# Altium schematic sheet Power.SchDoc
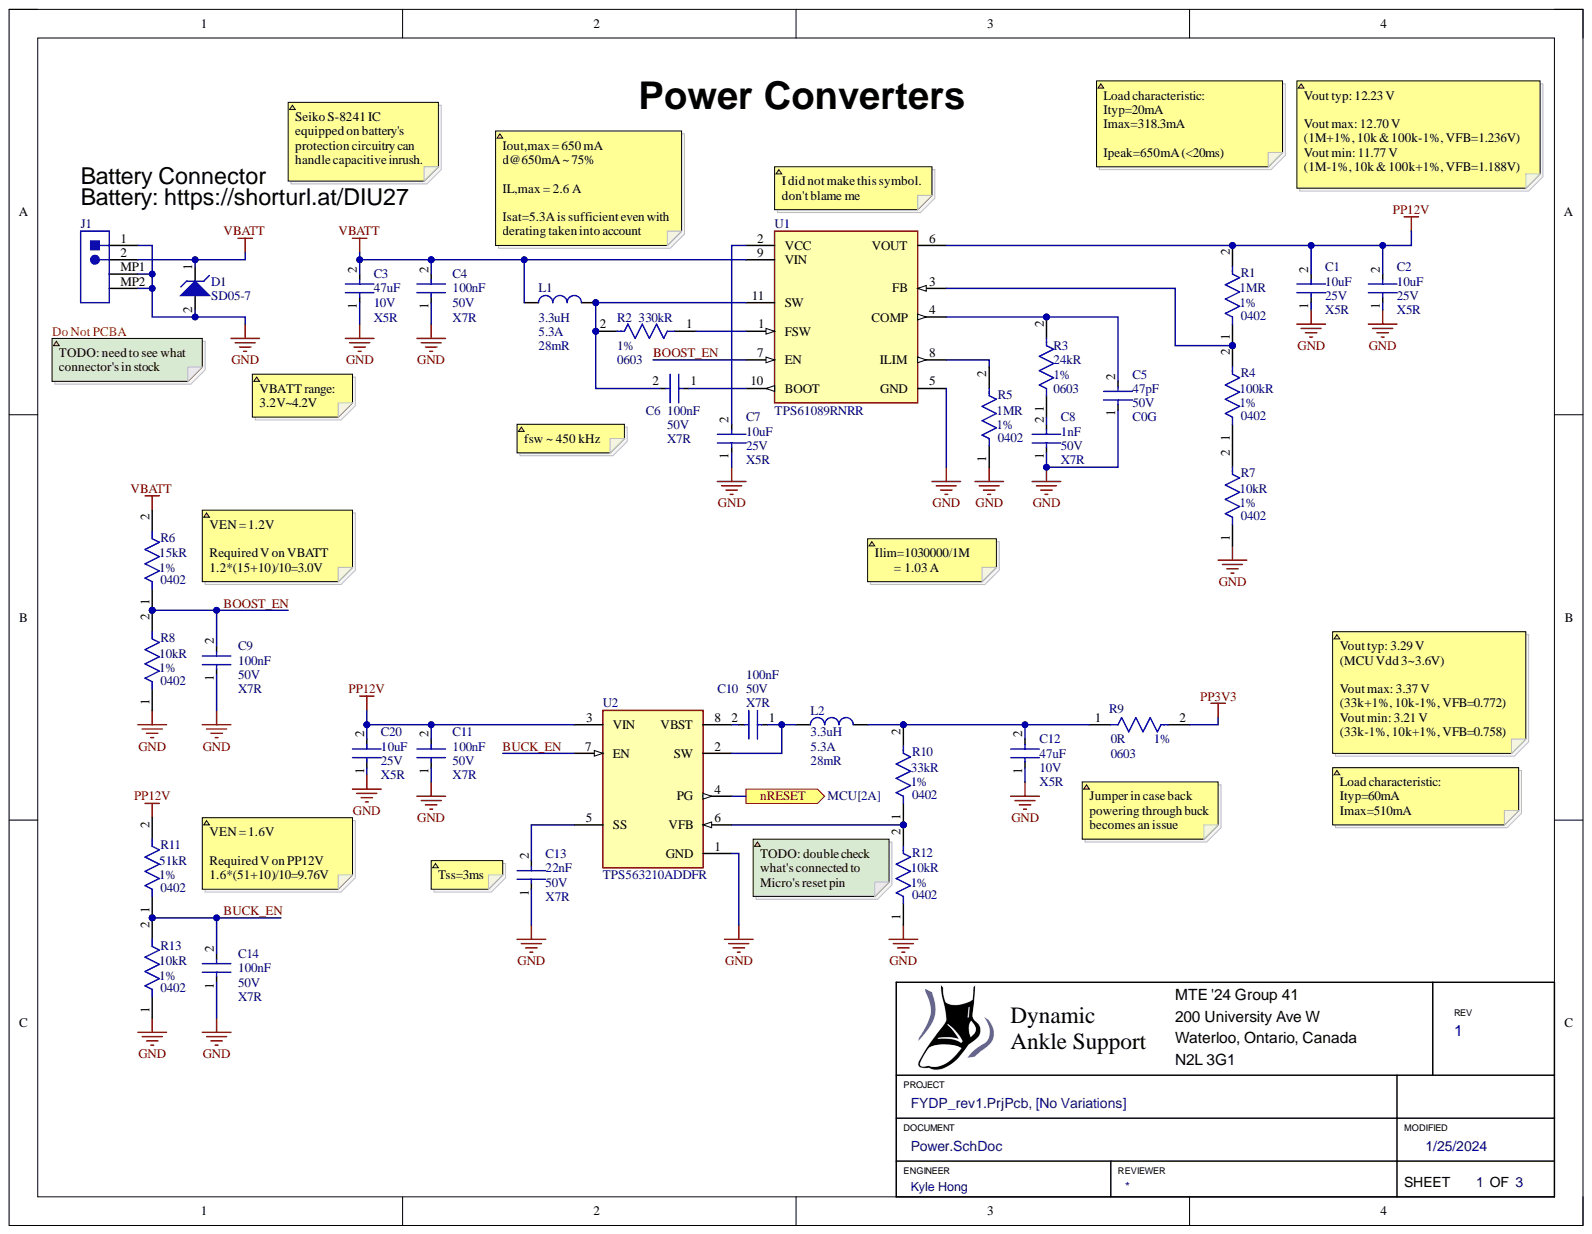
[diagram p0: Power.SchDoc]

## Parts
C1  Capacitance: 10uF  Dielectric: X5R  Voltage Rating: 25V  [CAP0805]  (hidden comment: CL21A106KAYNNNE)
C2  Capacitance: 10uF  Dielectric: X5R  Voltage Rating: 25V  [CAP0805]  (hidden comment: CL21A106KAYNNNE)
C3  Capacitance: 47uF  Dielectric: X5R  Voltage Rating: 10V  [CAP1206]  (hidden comment: CL31A476MPHNNNE)
C4  Capacitance: 100nF  Dielectric: X7R  Voltage Rating: 50V  [CAP0402]  (hidden comment: CL05B104KB54PNC)
C5  Capacitance: 47pF  Dielectric: C0G  Voltage Rating: 50V  [CAP0402]  (hidden comment: 0402CG470J500NT)
C6  Capacitance: 100nF  Voltage Rating: 50V  [CAP0402]  (hidden comment: CL05B104KB54PNC)
C7  Capacitance: 10uF  Dielectric: X5R  Voltage Rating: 25V  [CAP0805]  (hidden comment: CL21A106KAYNNNE)
C8  Capacitance: 1nF  Dielectric: X7R  Voltage Rating: 50V  [CAP0402]  (hidden comment: 0402B102K500NT)
C9  Capacitance: 100nF  Dielectric: X7R  Voltage Rating: 50V  [CAP0402]  (hidden comment: CL05B104KB54PNC)
C10  Dielectric: X7R  Voltage Rating: 50V  [CAP0402]  (hidden comment: CL05B104KB54PNC)
C11  Capacitance: 100nF  Dielectric: X7R  Voltage Rating: 50V  [CAP0402]  (hidden comment: CL05B104KB54PNC)
C12  Capacitance: 47uF  Dielectric: X5R  Voltage Rating: 10V  [CAP1206]  (hidden comment: CL31A476MPHNNNE)
C13  Capacitance: 22nF  Dielectric: X7R  Voltage Rating: 50V  [CAP0402]  (hidden comment: 0402B223K500NT)
C14  Capacitance: 100nF  Dielectric: X7R  Voltage Rating: 50V  [CAP0402]  (hidden comment: CL05B104KB54PNC)
C20  Capacitance: 10uF  Dielectric: X5R  Voltage Rating: 25V  [CAP0805]  (hidden comment: CL21A106KAYNNNE)
D1  SD05-7  [SD05-7]
J1  S2B-PH-SM4-TB(LF)(SN)  [JST- S2B-PH-SM4-TB(LF)(SN)-2_V]  (value not drawn)
L1  Inductance: 3.3uH  Isat(min): 5.3A  [TMPA0503S-3R3MN-D]  (hidden comment: TMPA0503S-3R3MN-D)
L2  Inductance: 3.3uH  Isat(min): 5.3A  [TMPA0503S-3R3MN-D]  (hidden comment: TMPA0503S-3R3MN-D)
R1  Package: 0402  Resistance: 1MR  Tolerance: 1%  [RES0402]  (hidden comment: 0402WGF1004TCE)
R2  Package: 0603  Resistance: 330kR  Tolerance: 1%  [RES0603]  (hidden comment: 0603WAF3303T5E)
R3  Package: 0603  Resistance: 24kR  Tolerance: 1%  [RES0603]  (hidden comment: 0603WAF2402T5E)
R4  Package: 0402  Resistance: 100kR  Tolerance: 1%  [RES0402]  (hidden comment: 0402WGF1003TCE)
R5  Package: 0402  Resistance: 1MR  Tolerance: 1%  [RES0402]  (hidden comment: 0402WGF1004TCE)
R6  Package: 0402  Resistance: 15kR  Tolerance: 1%  [RES0402]  (hidden comment: 0402WGF1502TCE)
R7  Package: 0402  Resistance: 10kR  Tolerance: 1%  [RES0402]  (hidden comment: 0402WGF1002TCE)
R8  Package: 0402  Resistance: 10kR  Tolerance: 1%  [RES0402]  (hidden comment: 0402WGF1002TCE)
R9  Package: 0603  Resistance: 0R  Tolerance: 1%  [RES0603]  (hidden comment: 0603WAF0000T5E)
R10  Package: 0402  Resistance: 33kR  Tolerance: 1%  [RES0402]  (hidden comment: 0402WGF3302TCE)
R11  Package: 0402  Resistance: 51kR  Tolerance: 1%  [RES0402]  (hidden comment: 0402WGF5102TCE)
R12  Package: 0402  Resistance: 10kR  Tolerance: 1%  [RES0402]  (hidden comment: 0402WGF1002TCE)
R13  Package: 0402  Resistance: 10kR  Tolerance: 1%  [RES0402]  (hidden comment: 0402WGF1002TCE)
U1  TPS61089RNRR  [FP-RNR0011A-MFG]
U2  TPS563210ADDFR  [TPS563210ADDFR]

## Ports
nRESET

## Nets
GND: C1.1 C2.1 C3.1 C4.1 C5.1 C7.1 C8.1 C9.1 C11.1 C12.1 C13.1 C14.1 C20.1 D1.2 J1.1 J1.MP1 J1.MP2 R5.1 R7.1 R8.1 R12.1 R13.1 U1.5(GND) U2.1(GND)
PP3V3: R9.2
PP12V: C1.2 C2.2 C11.2 C20.2 R1.2 R11.2 U1.6(VOUT) U2.3(VIN)
VBATT: C3.2 C4.2 D1.1 J1.2 L1.1 R6.2 U1.9(VIN)
BOOST_EN: C9.2 R6.1 R8.2 U1.7(EN)
BUCK_EN: C14.2 R11.1 R13.2 U2.7(EN)
nRESET: U2.4(PG) ; port nRESET
NetC5_2: C5.2 R3.2 U1.4(COMP)
NetC6_1: C6.1 U1.10(BOOT)
NetC6_2: C6.2 L1.2 R2.2 U1.11(SW)
NetC7_2: C7.2 U1.2(VCC)
NetC8_2: C8.2 R3.1
NetC10_1: C10.1 L2.1 U2.2(SW)
NetC10_2: C10.2 U2.8(VBST)
NetC12_2: C12.2 L2.2 R9.1 R10.2
NetC13_2: C13.2 U2.5(SS)
NetR1_1: R1.1 R4.2 U1.3(FB)
NetR2_1: R2.1 U1.1(FSW)
NetR4_1: R4.1 R7.2
NetR5_2: R5.2 U1.8(ILIM)
NetR10_1: R10.1 R12.2 U2.6(VFB)

## Library data of the parts
C1 C2 C7 C20 = CAP0805: 25V 10uF X5R ±10% 0805  Multilayer Ceramic Capacitors MLCC - SMD/SMT ROHS; Manufacturer: Samsung Electro-Mechanics; Component Kind: Standard; Manufacturer Part Number: CL21A106KAYNNNE; Package: 0805; Tolerance: 10%; Library Name: FYDP_library_sch.SchLib
C3 C12 = CAP1206: 10V 47uF X5R ±20% 1206  Multilayer Ceramic Capacitors MLCC - SMD/SMT ROHS; Manufacturer: Samsung Electro-Mechanics; Component Kind: Standard; Manufacturer Part Number: CL31A476MPHNNNE; Package: 1206; Tolerance: 20%; Library Name: FYDP_library_sch.SchLib
C4 C6 C9-C11 C14 = CAP0402: 50V 100nF X7R ±10% 0402  Multilayer Ceramic Capacitors MLCC - SMD/SMT ROHS; Manufacturer: Samsung Electro-Mechanics; Tolerance: ±20%; Component Kind: Standard; Manufacturer Part Number: CL05B104KB54PNC; Package: 0402; Library Name: FYDP_library_sch.SchLib
  C6: Dielectric: X7R
  C10: Capacitance: 100nF
C5 = CAP0402: 50V 47pF C0G ±5% 0402  Multilayer Ceramic Capacitors MLCC - SMD/SMT ROHS; Manufacturer: FH (Guangdong Fenghua Advanced Tech); Component Kind: Standard; Manufacturer Part Number: 0402CG470J500NT; Package: 0402; Tolerance: ±5%; Library Name: FYDP_library_sch.SchLib
C8 = CAP0402: 50V 1nF X7R ±10% 0402  Multilayer Ceramic Capacitors MLCC - SMD/SMT ROHS; Manufacturer: FH (Guangdong Fenghua Advanced Tech); Component Kind: Standard; Manufacturer Part Number: 0402B102K500NT; Package: 0402; Tolerance: ±10%; Library Name: FYDP_library_sch.SchLib
C13 = CAP0402: 50V 22nF X7R ±10% 0402  Multilayer Ceramic Capacitors MLCC - SMD/SMT ROHS; Manufacturer: FH (Guangdong Fenghua Advanced Tech); Component Kind: Standard; Manufacturer Part Number: 0402B223K500NT; Package: 0402; Tolerance: 10%; Library Name: FYDP_library_sch.SchLib
D1 = SD05-7: TVS UNI14.5V 6.2V 5V SOD-323; Manufacturer: Diodes Incorporated; Clamping Voltage: 14.5V; Breakdown Voltage: 6.2V; Component Kind: Standard; Manufacturer Part Number: SD05-7; Package: SOD-323; Reverse Standoff Voltage: 5V; Library Name: FYDP_library_sch.SchLib
J1 = CMP-1755-00001-1: Male Header, Pitch 2 mm, 1 x 2 Position, Height 5.5 mm, -25 to 85 degC, RoHS, Tape and Reel; Current Rating: 3 A; Colour: Black; Component Kind: Standard; ComponentLink1Description: Manufacturer URL; ComponentLink1URL: http://www.jst.com/home2.html; ComponentLink2Description: Datasheet; ComponentLink2URL: http://www.jst-mfg.com/product/pdf/eng/ePH.pdf; Contact Finish: Lead; Contact Material: Bronze; Contact Plating: Tin; DatasheetVersion: Dec-12; Height: 8.13 mm; Lead Pitch: 0.1 inch; Length: 101.19 mm; Library Name: Altium Content Vault; Max Operating Temperature: 105degC; Min Operating Temperature: -65degC; Mount: Through Hole; Package Quantity: 100; PackageDescription: Male Header, Pitch 2 mm, 1 x 2 Position, Height 5.5 mm; PackageReference: S2B-PH-SM4-TB(LF)(SN)-2; Packaging: Bulk; Pins: 40; Radiation Hardening: No; RoHSCompliant: True; Width: 2.34 mm
L1 L2 = TMPA0503S-3R3MN-D: INDUCTOR 5.3A 3.3uH ±20% SMD Power Inductors ROHS; Manufacturer: TAI-TECH; DC Resistance: 28mR; Component Kind: Standard; Manufacturer Part Number: TMPA0503S-3R3MN-D; Tolerance: 20%; Library Name: FYDP_library_sch.SchLib
R1 R5 = RES0402: 62.5mW Thick Film Resistors ±100ppm/℃ ±1% 1MΩ 0402  Chip Resistor - Surface Mount ROHS; Manufacturer: UNI-ROYAL(Uniroyal Elec); Component Kind: Standard; Manufacturer Part Number: 0402WGF1004TCE; Power Rating: 62.5mW; Library Name: FYDP_library_sch.SchLib
R2 = RES0603: 100mW Thick Film Resistors ±100ppm/℃ ±1% 330kΩ 0603  Chip Resistor - Surface Mount ROHS; Manufacturer: UNI-ROYAL(Uniroyal Elec); Component Kind: Standard; Manufacturer Part Number: 0603WAF3303T5E; Power Rating: 100mW; Library Name: FYDP_library_sch.SchLib
R3 = RES0603: 100mW Thick Film Resistors ±100ppm/℃ ±1% 24kΩ 0603  Chip Resistor - Surface Mount ROHS; Manufacturer: UNI-ROYAL(Uniroyal Elec); Component Kind: Standard; Manufacturer Part Number: 0603WAF2402T5E; Power Rating: 100mW; Library Name: FYDP_library_sch.SchLib
R4 = RES0402: 62.5mW Thick Film Resistors ±100ppm/℃ ±1% 100kΩ 0402  Chip Resistor - Surface Mount ROHS; Manufacturer: UNI-ROYAL(Uniroyal Elec); Component Kind: Standard; Manufacturer Part Number: 0402WGF1003TCE; Power Rating: 62.5mW; Library Name: FYDP_library_sch.SchLib
R6 = RES0402: 62.5mW Thick Film Resistors ±100ppm/℃ ±1% 15kΩ 0402  Chip Resistor - Surface Mount ROHS; Manufacturer: UNI-ROYAL(Uniroyal Elec); Component Kind: Standard; Manufacturer Part Number: 0402WGF1502TCE; Power Rating: 62.5mW; Library Name: FYDP_library_sch.SchLib
R7 R8 R12 R13 = RES0402: 62.5mW Thick Film Resistors ±100ppm/℃ ±1% 10kΩ 0402  Chip Resistor - Surface Mount ROHS; Manufacturer: UNI-ROYAL(Uniroyal Elec); Component Kind: Standard; Manufacturer Part Number: 0402WGF1002TCE; Power Rating: 62.5mW; Library Name: FYDP_library_sch.SchLib
R9 = RES0603: 100mW Thick Film Resistors ±1% 0Ω 0603  Chip Resistor - Surface Mount ROHS; Manufacturer: UNI-ROYAL(Uniroyal Elec); Component Kind: Standard; Manufacturer Part Number: 0603WAF0000T5E; Power Rating: 100mW; Library Name: FYDP_library_sch.SchLib
R10 = RES0402: 62.5mW Thick Film Resistors ±100ppm/℃ ±1% 33kΩ 0402  Chip Resistor - Surface Mount ROHS; Manufacturer: UNI-ROYAL(Uniroyal Elec); Component Kind: Standard; Manufacturer Part Number: 0402WGF3302TCE; Power Rating: 62.5mW; Library Name: FYDP_library_sch.SchLib
R11 = RES0402: 62.5mW Thick Film Resistors ±100ppm/℃ ±1% 51kΩ 0402  Chip Resistor - Surface Mount ROHS; Manufacturer: UNI-ROYAL(Uniroyal Elec); Component Kind: Standard; Manufacturer Part Number: 0402WGF5102TCE; Power Rating: 62.5mW; Library Name: FYDP_library_sch.SchLib
U1 = CMP-04918-000107-1: IC REG BOOST ADJ 7A 11VQFN; Manufacturer: Texas Instruments; Max Duty Cycle: 90%; Max Junction Temp: +125°C; Max Supply Voltage: 12V; Min Supply Voltage: 2.7V; Nominal Supply Current: 10uA; Number Of Outputs: 1; Output Current: 8.1-10A; Output Type: AdjustableProgrammable; Output Voltage: 4.5-12.6V; Standoff Height: 0mm; Switching Frequency: 200-2200kHz; Automotive: No; Category: IC; Component Kind: Standard; ComponentLink2Description: Datasheet; ComponentLink2URL: http://www.ti.com/lit/ds/symlink/tps61089.pdf; Device Class L1: Integrated Circuits (ICs); Device Class L2: Power Management ICs; Device Class L3: Voltage Regulators - Switching; Digikey Description: IC REG BOOST ADJ 7A 11VQFN; Height: 1mm; Lead Free: Yes; Library Name: Altium Content Vault; Manufacturer Part Number: TPS61089RNRR; Octopart: https://octopart.com/tps61089rnrr-texas+instruments-68059594; Package: 11VQFN; RoHS: TRUE; Switching Topology: Boost; Temperature: -40°C to +85°C; Temperature Range High: +85°C; Temperature Range Low: -40°C
U2 = TPS563210ADDFR: IC REG BUCK ADJ 3A SOT-23-8; Manufacturer: Texas Instruments; Component Kind: Standard; Manufacturer Part Number: TPS563210ADDFR; Package: SOT-23-8; Supplier 1: Mouser; Supplier Part Number 1: 595-TPS563210ADDFR; Library Name: FYDP_library_sch.SchLib
